# Altium schematic sheet MotorDriver_v1.1.SchDoc
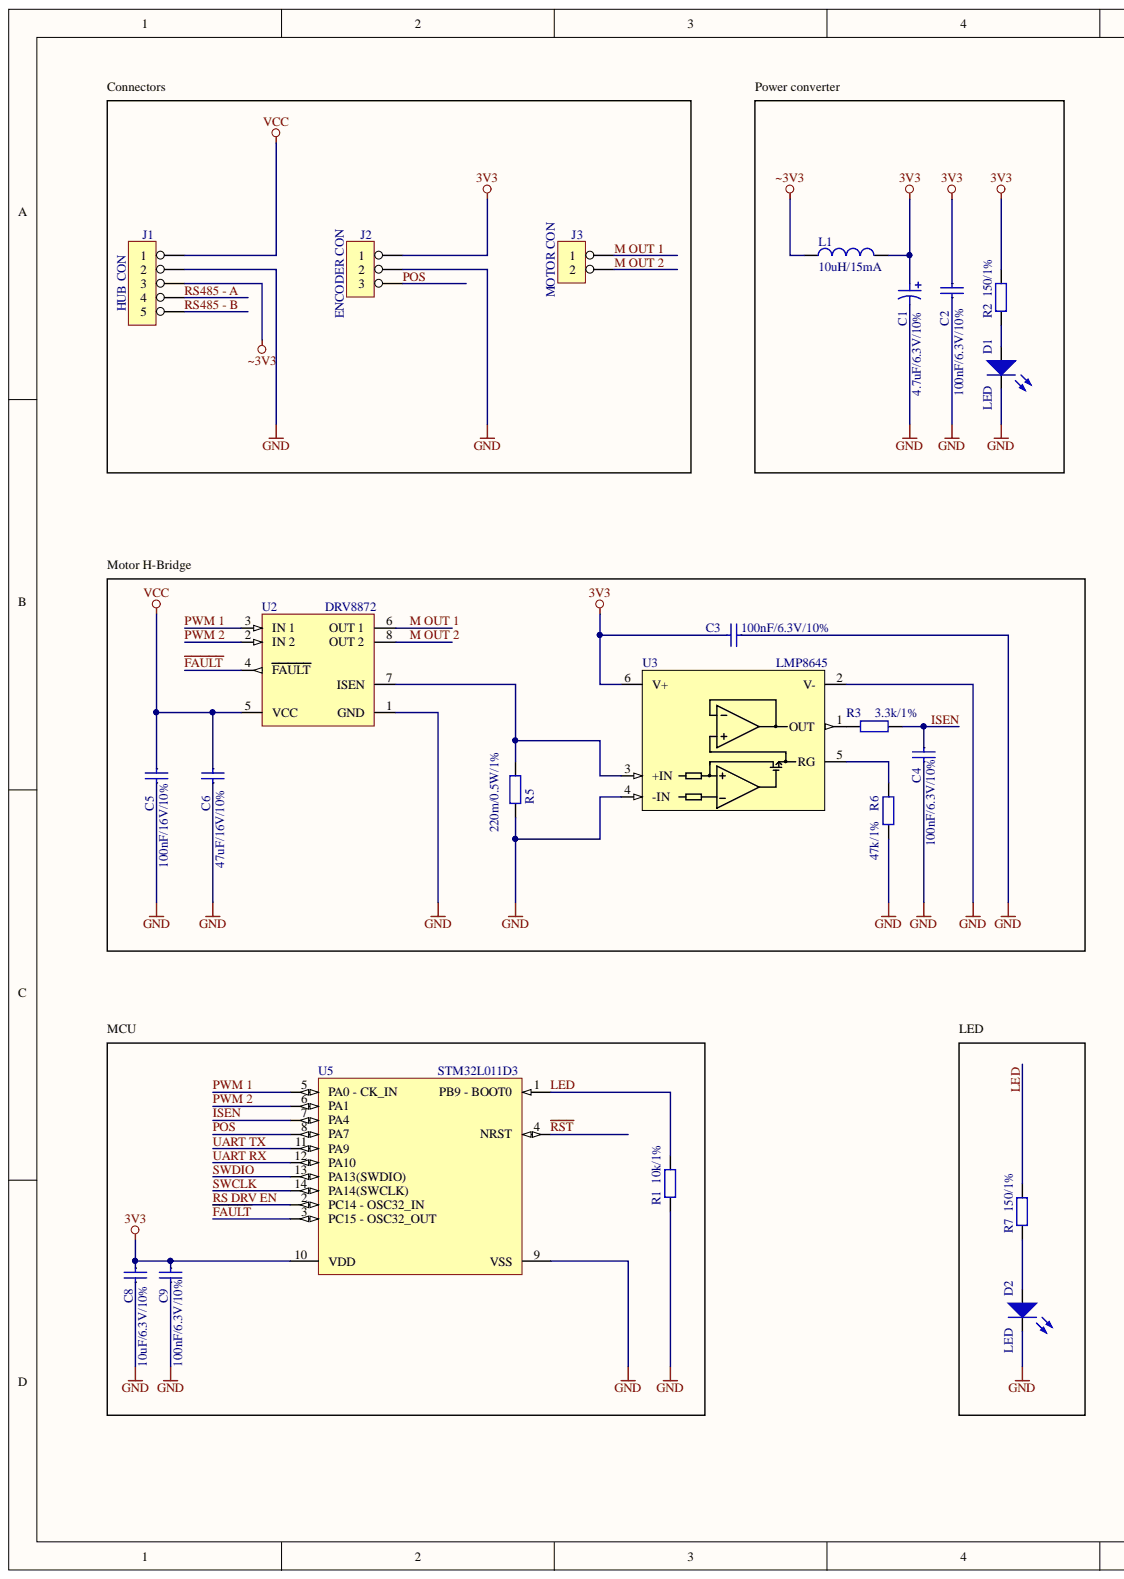
[diagram p0: MotorDriver_v1.1.SchDoc, left]
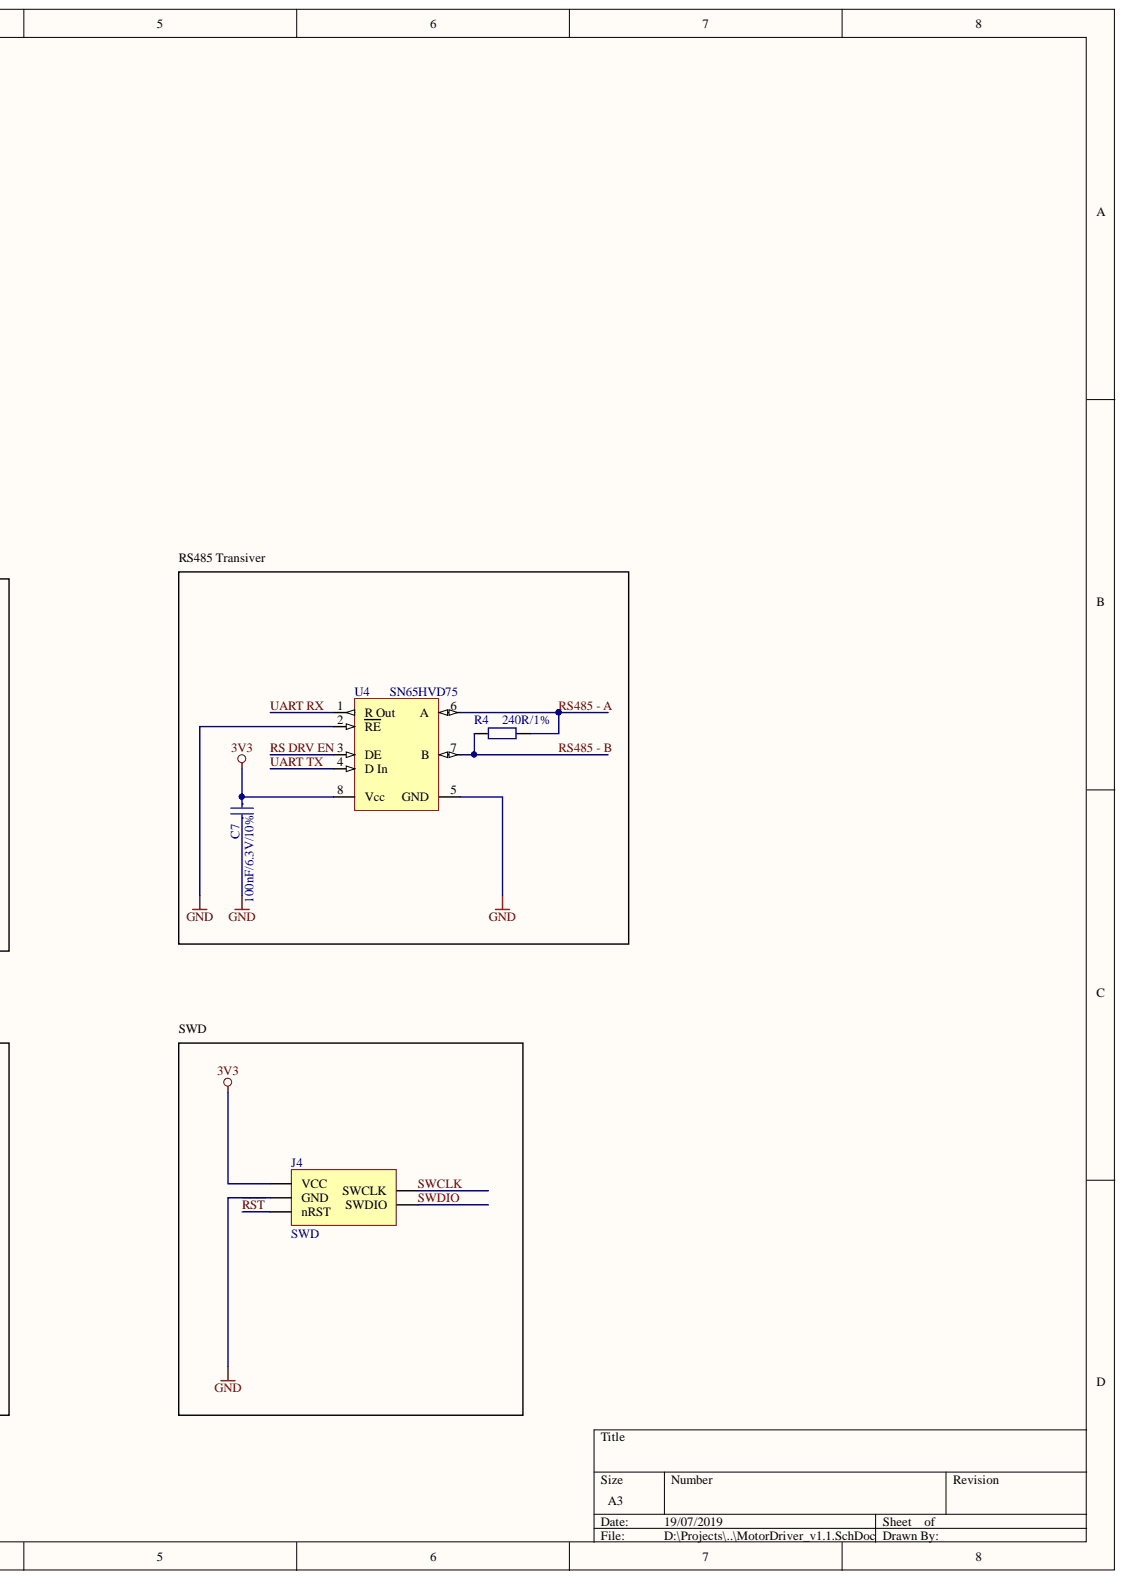
[diagram p1: MotorDriver_v1.1.SchDoc, right]

## Parts
C1  4.7uF/6.3V/10%  [TANT_A]
C2  100nF/6.3V/10%  [CHIP_C_0603]
C3  100nF/6.3V/10%  [CHIP_C_0603]
C4  100nF/6.3V/10%  [CHIP_C_0603]
C5  100nF/16V/10%  [CHIP_C_0603]
C6  47uF/16V/10%  [CHIP_C_1210]
C7  100nF/6.3V/10%  [CHIP_C_0603]
C8  10uF/6.3V/10%  [CHIP_C_0603]
C9  100nF/6.3V/10%  [CHIP_C_0603]
D1  LED  [CHIP_LED_0603]
D2  LED  [CHIP_LED_0603]
J1  HUB CON  [5 PAD - silicon wire]
J2  ENCODER CON  [3 PAD - silicon wire]
J3  MOTOR CON  [2 PAD - silicon wire]
J4  SWD  [SWD - POGO]
L1  10uH/15mA  [chip_L_0805]
R1  10k/1%  [CHIP_R_0603]
R2  150/1%  [CHIP_R_0603]
R3  3.3k/1%  [CHIP_R_0603]
R4  240R/1%  [CHIP_R_0603]
R5  220m/0.5W/1%  [chip_r_1206]
R6  47k/1%  [CHIP_R_0603]
R7  150/1%  [CHIP_R_0603]
U2  DRV8872  [SO8 PowerPAD (R-PDSO-G8)]
U3  LMP8645  [SOT23-6 - THIN]
U4  SN65HVD75  [MSOP-8]
U5  STM32L011D3  [TSSOP14_N]

## Nets
3V3: C1.1 C2.2 C3.2 C7.2 C8.2 C9.2 J2.1 J4.VCC L1.2 R2.2 U3.6(V+) U4.8(Vcc) U5.10(VDD)
GND: C1.2 C2.1 C3.1 C4.1 C5.1 C6.1 C7.1 C8.1 C9.1 D1.2 D2.2 J1.2 J2.2 J4.GND R1.1 R5.2 R6.1 U2.1(GND) U2.PowerPAD U3.2(V-) U3.4(-IN) U4.2(RE) U4.5(GND) U5.9(VSS)
VCC: C5.2 C6.2 J1.1 U2.5(VCC)
~3V3: J1.3 L1.1
~{FAULT}: U2.4(FAULT) U5.3(PC15_-_OSC32_OUT)
ISEN: C4.2 R3.1 U5.7(PA4)
LED: R1.2 R7.2 U5.1(PB9_-_BOOT0)
M OUT 1: J3.1 U2.6(OUT_1)
M OUT 2: J3.2 U2.8(OUT_2)
POS: J2.3 U5.8(PA7)
PWM 1: U2.3(IN_1) U5.5(PA0_-_CK_IN)
PWM 2: U2.2(IN_2) U5.6(PA1)
~{RST}: J4.nRST U5.4(NRST)
RS485 - A: J1.4 R4.1 U4.6(A)
RS485 - B: J1.5 R4.2 U4.7(B)
RS DRV EN: U4.3(DE) U5.2(PC14_-_OSC32_IN)
SWCLK: J4.SWCLK U5.14(PA14(SWCLK))
SWDIO: J4.SWDIO U5.13(PA13(SWDIO))
UART RX: U4.1(R_Out) U5.12(PA10)
UART TX: U4.4(D_In) U5.11(PA9)
NetD1_1: D1.1 R2.1
NetD2_1: D2.1 R7.1
NetR3_2: R3.2 U3.1(OUT)
NetR5_1: R5.1 U2.7(ISEN) U3.3(+IN)
NetR6_2: R6.2 U3.5(RG)

## Library data of the parts
C1 = C Pol: Polarized Capacitor; Component Kind: Standard; Library Name: elementy_bierne.IntLib
C2-C9 = C: Capacitor; Component Kind: Standard; Library Name: elementy_bierne.IntLib
J1 = PIN5: 5 Pin Connector; Component Kind: Standard; Library Name: elementy_stykowe.IntLib; Publisher: Kamil Pawliczak
J2 = PIN3: 3 Pin Connector; Component Kind: Standard; Library Name: elementy_stykowe.IntLib; Publisher: Kamil Pawliczak
J3 = PIN2: 2 Pin Connector; Component Kind: Standard; Library Name: elementy_stykowe.IntLib; Publisher: Kamil Pawliczak
J4 = SWD: SWD Connector; Component Kind: Standard; Library Name: elementy_stykowe.IntLib
L1 = L: Inductor; Value: 10uH; Component Kind: Standard; HelpURL: c:\altium\biblioteki\elementy_bierne\doc\cewka.txt; Library Name: elementy_bierne.IntLib; Publisher: Kamil Pawliczak
R1-R7 = R: Resistor; Component Kind: Standard; Library Name: elementy_bierne.IntLib
U2 = DRV8872: 3.6-A Brushed DC Motor Driver With Fault Reporting (PWM Control); Component Kind: Standard; Library Name: uklady_scalone.IntLib
U3 = LMP8645: Precision High Voltage Current Sense Amplifier; Component Kind: Standard; Library Name: uklady_scalone.IntLib
U4 = SN65HVD75: 3.3 V Half-Duplex RS-485, with IEC ESD, 20 Mbps; Component Kind: Standard; Library Name: uklady_scalone.IntLib
U5 = STM32L011D3: Ultra-low-power ARM Cortex-M0+ MCU with 8-Kbytes Flash, 32 MHz CPU; Component Kind: Standard; Library Name: uklady_scalone.IntLib
D1 D2 = LED: Component Kind: Standard; Description: LED; Library Name: optoelektroniczne.IntLib; Publisher: Kamil Pawliczak
